# Altium schematic sheet input_module.SchDoc
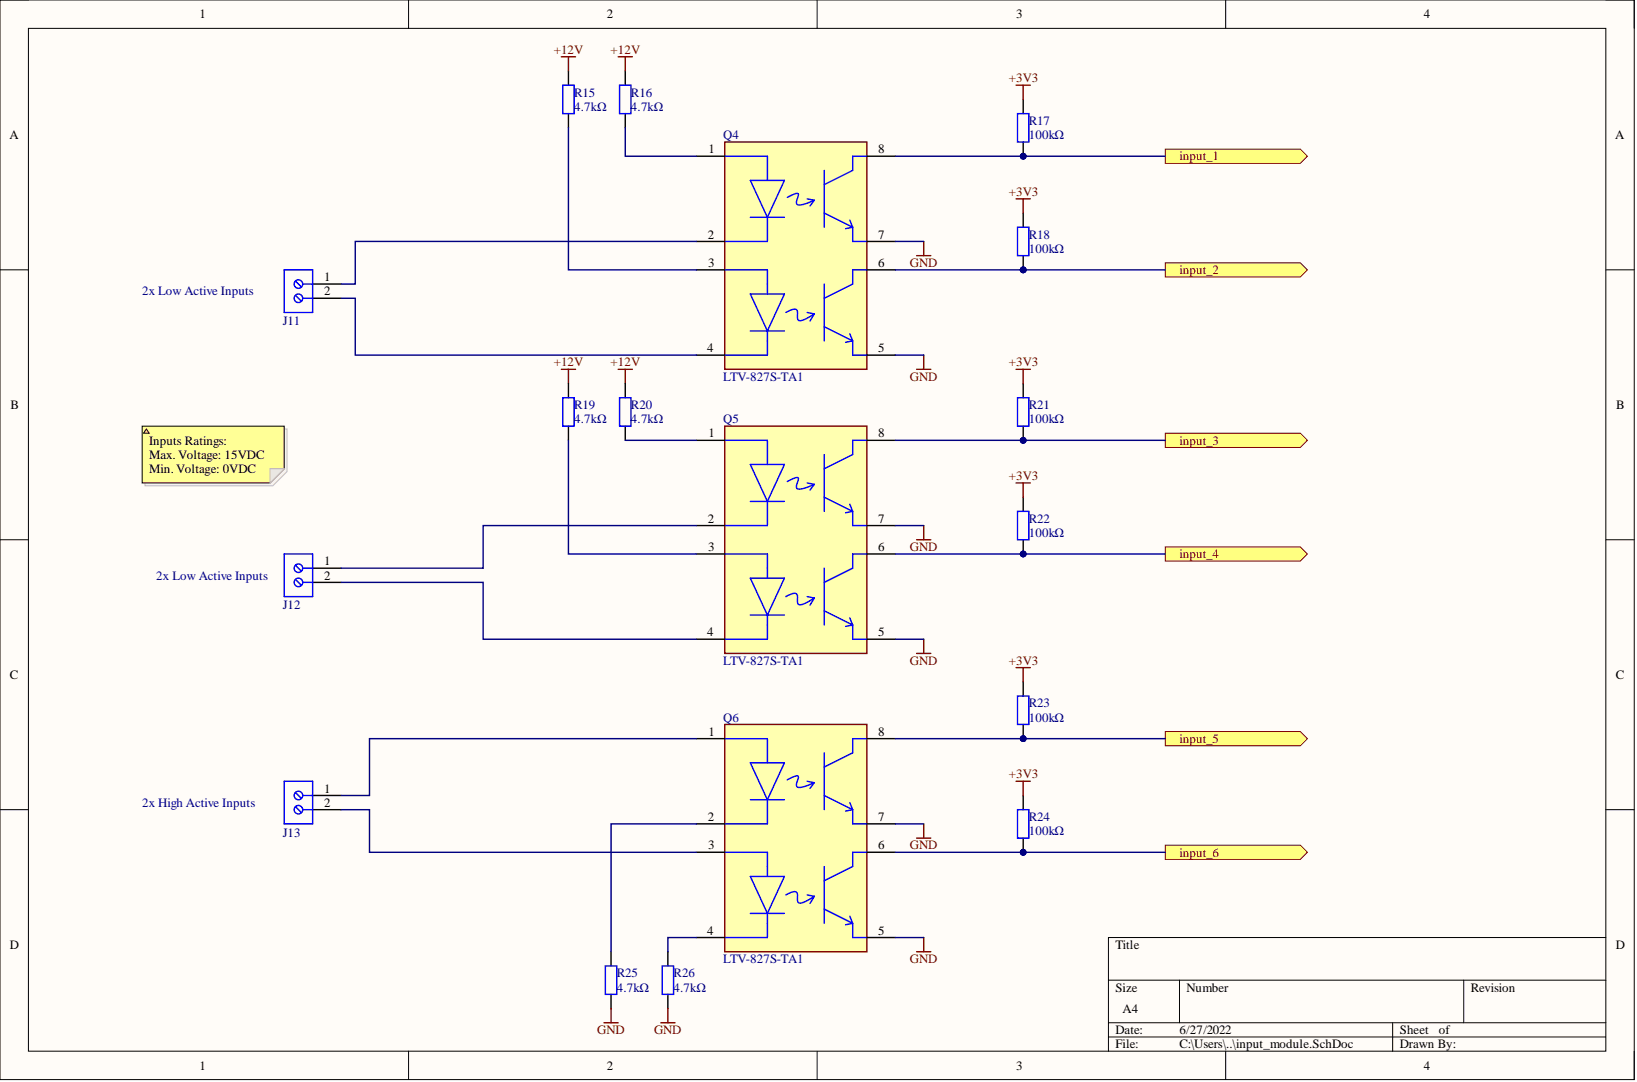
[diagram p0: input_module.SchDoc]

## Parts
J11  282836-2  [TECO-282836-2_V]  (value not drawn)
J12  282836-2  [TECO-282836-2_V]  (value not drawn)
J13  282836-2  [TECO-282836-2_V]  (value not drawn)
Q4  LTV-827S-TA1  [FP-LTV-827S-TA1-MFG]
Q5  LTV-827S-TA1  [FP-LTV-827S-TA1-MFG]
Q6  LTV-827S-TA1  [FP-LTV-827S-TA1-MFG]
R15  4.7kΩ  [RESC2013X50X30ML20T20]
R16  4.7kΩ  [RESC2013X50X30ML20T20]
R17  100kΩ  [RESC2013X50X30ML20T20]
R18  100kΩ  [RESC2013X50X30ML20T20]
R19  4.7kΩ  [RESC2013X50X30ML20T20]
R20  4.7kΩ  [RESC2013X50X30ML20T20]
R21  100kΩ  [RESC2013X50X30ML20T20]
R22  100kΩ  [RESC2013X50X30ML20T20]
R23  100kΩ  [RESC2013X50X30ML20T20]
R24  100kΩ  [RESC2013X50X30ML20T20]
R25  4.7kΩ  [RESC2013X50X30ML20T20]
R26  4.7kΩ  [RESC2013X50X30ML20T20]

## Ports
input_1, input_2, input_3, input_4, input_5, input_6

## Nets
+3V3: R17.1 R18.1 R21.1 R22.1 R23.1 R24.1
+12V: R15.1 R16.1 R19.1 R20.1
GND: Q4.5 Q4.7 Q5.5 Q5.7 Q6.5 Q6.7 R25.2 R26.2
input_1: Q4.8 R17.2 ; port input_1
input_2: Q4.6 R18.2 ; port input_2
input_3: Q5.8 R21.2 ; port input_3
input_4: Q5.6 R22.2 ; port input_4
input_5: Q6.8 R23.2 ; port input_5
input_6: Q6.6 R24.2 ; port input_6
NetJ11_1: J11.1 Q4.2
NetJ11_2: J11.2 Q4.4
NetJ12_1: J12.1 Q5.2
NetJ12_2: J12.2 Q5.4
NetJ13_1: J13.1 Q6.1
NetJ13_2: J13.2 Q6.3
NetQ4_1: Q4.1 R16.2
NetQ4_3: Q4.3 R15.2
NetQ5_1: Q5.1 R20.2
NetQ5_3: Q5.3 R19.2
NetQ6_2: Q6.2 R25.1
NetQ6_4: Q6.4 R26.1

## Library data of the parts
J11-J13 = CMP-1684-00017-1: Female Header, Pitch 5 mm, 1 x 2 Position, Height 10 mm, Tail Length 3.5 mm, Rohs, Bulk; Manufacturer: TE Connectivity; 3D Model: 282836-2.pdf; Clamp Material - Plating: Brass-NickelPlated; Current: 13.5A; Dynamic Catalog: BuchananSeries; Featured Product: EurostyleTerminalBlockConnectors; Mating Orientation: HorizontalwithBoard; Number of Levels: 1; Other Names: 5ULV-02B  A98076; Pitch: 0.197"(5.00mm); Positions Per Level: 2; Product Training Modules: Wire-to-BoardEurostyleTerminalBlocks; Screw Thread: M3; Torque - Screw: 0.68Nm(6Lb-In); Voltage: 300V; Wire Gauge: 16-30AWG; Wire Termination: Screw-RisingCageClamp; Category: Connectors,Interconnects; Color: Green; Component Kind: Standard; Contact Material - Plating: Brass-TinPlated; Datasheet URL: http://www.te.com.cn/commerce/DocumentDelivery/DDEController?Action=showdoc&DocId=Catalog+Section%7F1308389_EUROSTYLE_TERMINAL_BLOCKS%7F0607%7Fpdf%7FEnglish%7FENG_CS_1308389_EUROSTYLE_TERMINAL_BLOCKS_0607.pdf%7F282836-2; Family: TerminalBlocks-WiretoBoard; Features: Interlocking(Side); Housing Material: Polyamide(PA66),Nylon6/6; Library Name: Altium Content Vault; Manufacturer URL: http://www.te.com; Mounting Type: ThroughHole; Package Description: Female Header, Pitch 5 mm, 1 x 2 Position, Height 10 mm, Tail Length 3.5 mm; Package Reference: THD; Packaging: Bulk; RoHS Information: 282836-2StatementofCompliance; Screw Material - Plating: Brass-NickelPlated; Series: Buchanan; Standard Package: 300
Q4-Q6 = CMP-22018-000005-1: OPTOISOLATOR 5KV 2CH TRANS 8-SMD; Collector Emitter Saturation Voltage: 200mV; Collector Emitter Voltage (VCEO): 35V; Current Transfer Ratio: 600%; Fall Time: 18000ns; Forward Current: 50mA; Forward Voltage: 1.4V; Max Power Dissipation: 200mW; Number of Channels: 2; Reverse Breakdown Voltage: 6V; Case/Package: SMD/SMT; Component Kind: Standard; Isolation Voltage: 5kV; Lead Free: Lead Free; Library Name: Altium Content Vault; Max Collector Current: 50mA; Max Input Current: 50mA; Max Operating Temperature: 110°C; Max Output Voltage: 35V; Min Operating Temperature: -30°C; Mount: Surface Mount; Number of Circuits: 2; Number of Elements: 2; Number of Pins: 8; Output Current per Channel: 50mA; Output Voltage: 35V; Package Quantity: 1000; Packaging: Tape and Reel; Power Dissipation: 200mW; Radiation Hardening: No; Rise Time: 18000ns
R15-R26 = Res_0805: Resistor; Component Kind: Standard; Library Name: PCB_Project.SchLib; Signal Integrity: Res; Simulation: RESISTOR
